# Altium schematic sheet Processor.SchDoc
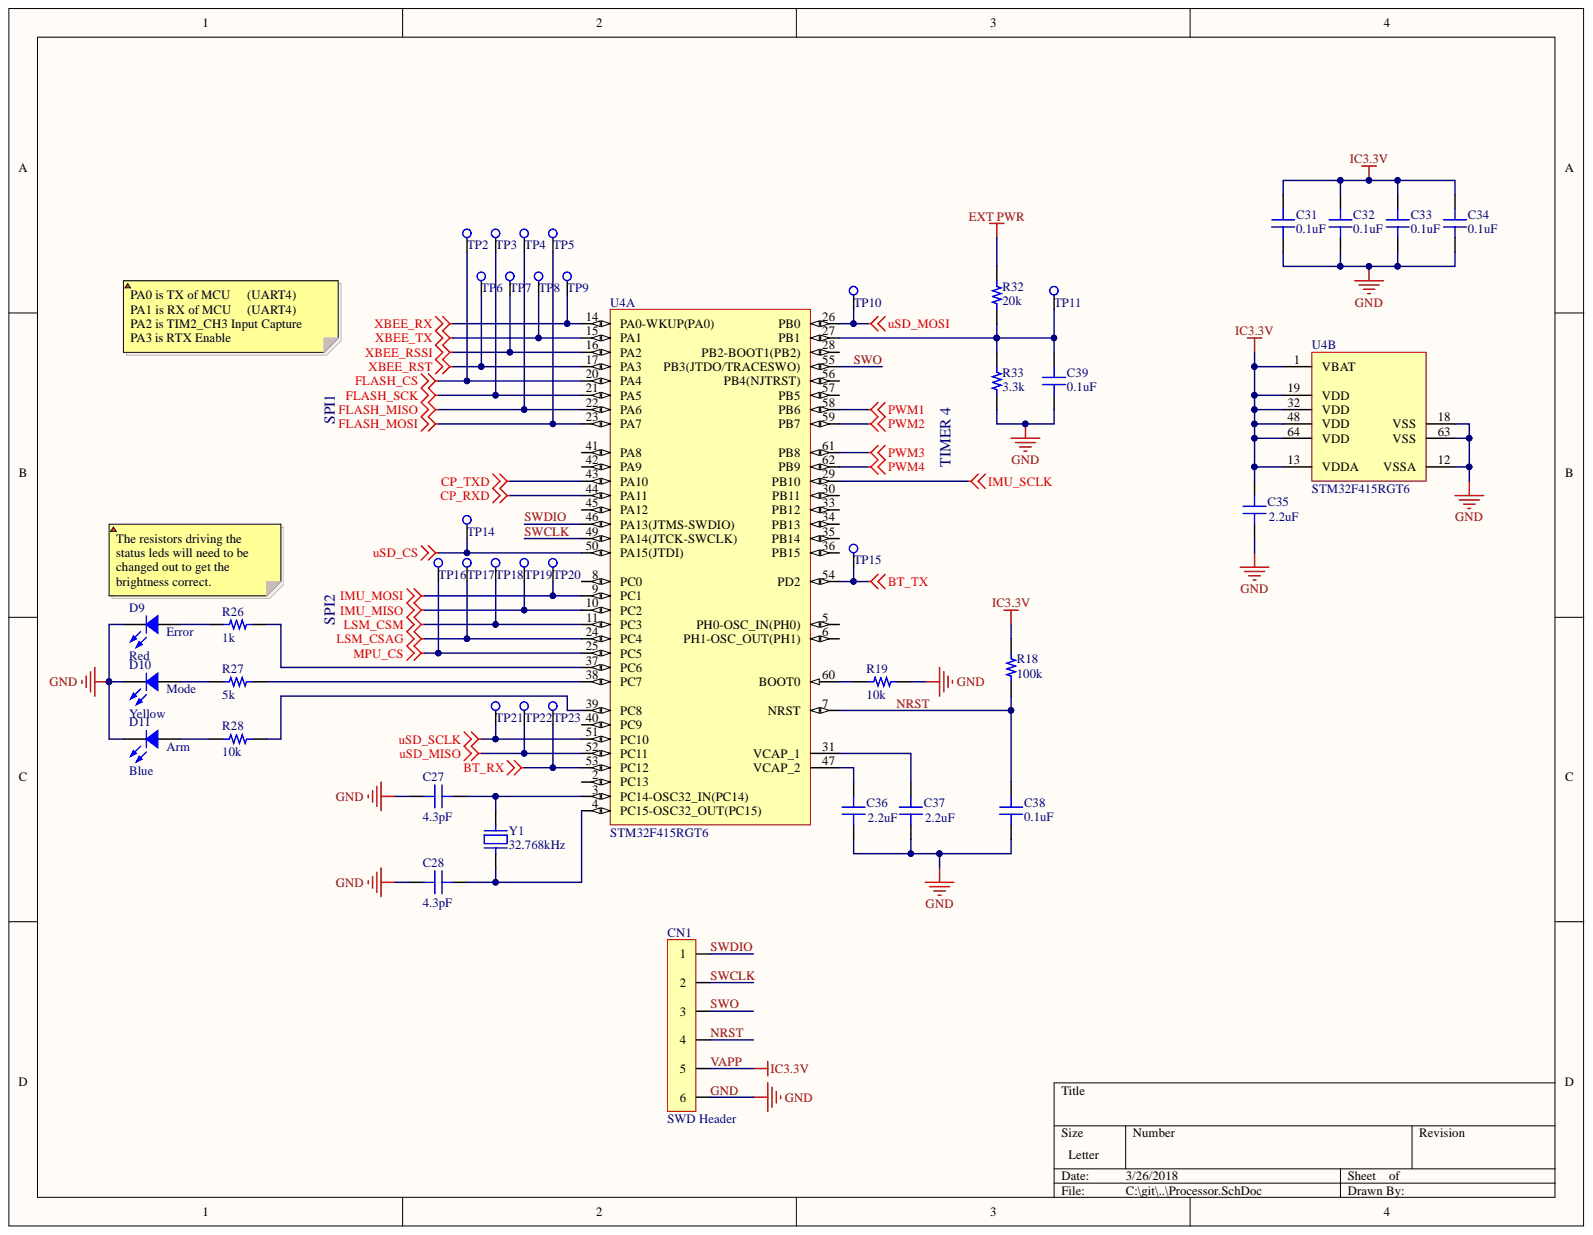
[diagram p0: Processor.SchDoc]

## Parts
C27  4.3pF  [CAP 0603/1608]
C28  4.3pF  [CAP 0603/1608]
C31  0.1uF  [CAP 0603/1608]
C32  0.1uF  [CAP 0603/1608]
C33  0.1uF  [CAP 0603/1608]
C34  0.1uF  [CAP 0603/1608]
C35  2.2uF  [CAP 0603/1608]
C36  2.2uF  [CAP 0603/1608]
C37  2.2uF  [CAP 0603/1608]
C38  0.1uF  [CAP 0603/1608]
C39  0.1uF  [CAP 0603/1608]
CN1  SWD Header  [Header_1x6]
D9  Red  [LED 0603/1608 RED]
D10  Yellow  [LED 0603/1608 YELLOW]
D11  Blue  [LED 0603/1608 BLUE]
R18  100k  [RES 0603/1608]
R19  10k  [RES 0603/1608]
R26  1k  [RES 0603/1608]
R27  5k  [RES 0603/1608]
R28  10k  [RES 0603/1608]
R32  20k  [RES 0603/1608]
R33  3.3k  [RES 0603/1608]
TP2  RCT-0C  [TE TP 0805]  (value not drawn)
TP3  RCT-0C  [TE TP 0805]  (value not drawn)
TP4  RCT-0C  [TE TP 0805]  (value not drawn)
TP5  RCT-0C  [TE TP 0805]  (value not drawn)
TP6  RCT-0C  [TE TP 0805]  (value not drawn)
TP7  RCT-0C  [TE TP 0805]  (value not drawn)
TP8  RCT-0C  [TE TP 0805]  (value not drawn)
TP9  RCT-0C  [TE TP 0805]  (value not drawn)
TP10  RCT-0C  [TE TP 0805]  (value not drawn)
TP11  RCT-0C  [TE TP 0805]  (value not drawn)
TP14  RCT-0C  [TE TP 0805]  (value not drawn)
TP15  RCT-0C  [TE TP 0805]  (value not drawn)
TP16  RCT-0C  [TE TP 0805]  (value not drawn)
TP17  RCT-0C  [TE TP 0805]  (value not drawn)
TP18  RCT-0C  [TE TP 0805]  (value not drawn)
TP19  RCT-0C  [TE TP 0805]  (value not drawn)
TP20  RCT-0C  [TE TP 0805]  (value not drawn)
TP21  RCT-0C  [TE TP 0805]  (value not drawn)
TP22  RCT-0C  [TE TP 0805]  (value not drawn)
TP23  RCT-0C  [TE TP 0805]  (value not drawn)
U4  STM32F415RGT6  [STM-LQFP64_N]  (parts A,B)
Y1  32.768kHz  [ABRACON ABS25]

## Nets
BT_RX: TP23.1 U4.53(PC12)
BT_TX: TP15.1 U4.54(PD2)
CP_RXD: U4.44(PA11)
CP_TXD: U4.43(PA10)
EXT PWR: R32.2
FLASH_CS: TP2.1 U4.20(PA4)
FLASH_MISO: TP4.1 U4.22(PA6)
FLASH_MOSI: TP5.1 U4.23(PA7)
FLASH_SCK: TP3.1 U4.21(PA5)
IMU_MISO: TP19.1 U4.10(PC2)
IMU_MOSI: TP20.1 U4.9(PC1)
IMU_SCLK: U4.29(PB10)
LSM_CSAG: TP17.1 U4.24(PC4)
LSM_CSM: TP18.1 U4.11(PC3)
MPU_CS: TP16.1 U4.25(PC5)
PWM1: U4.58(PB6)
PWM2: U4.59(PB7)
PWM3: U4.61(PB8)
PWM4: U4.62(PB9)
uSD_CS: TP14.1 U4.50(PA15(JTDI))
uSD_MISO: TP22.1 U4.52(PC11)
uSD_MOSI: TP10.1 U4.26(PB0)
uSD_SCLK: TP21.1 U4.51(PC10)
XBEE_RSSI: TP7.1 U4.16(PA2)
XBEE_RST: TP6.1 U4.17(PA3)
XBEE_RX: TP9.1 U4.14(PA0-WKUP(PA0))
XBEE_TX: TP8.1 U4.15(PA1)
GND: C27.1 C28.1 C31.1 C32.1 C33.1 C34.1 C35.1 C36.1 C37.1 C38.1 C39.1 CN1.6 D9.2 D10.2 D11.2 R19.2 R33.1 U4.12(VSSA) U4.18(VSS) U4.63(VSS)
NRST: C38.2 CN1.4 R18.1 U4.7(NRST)
SWCLK: CN1.2 U4.49(PA14(JTCK-SWCLK))
SWDIO: CN1.1 U4.46(PA13(JTMS-SWDIO))
SWO: CN1.3 U4.55(PB3(JTDO/TRACESWO))
VAPP: C31.2 C32.2 C33.2 C34.2 C35.2 CN1.5 R18.2 U4.1(VBAT) U4.13(VDDA) U4.19(VDD) U4.32(VDD) U4.48(VDD) U4.64(VDD)
NetC27_2: C27.2 U4.3(PC14-OSC32_IN(PC14)) Y1.1
NetC28_2: C28.2 U4.4(PC15-OSC32_OUT(PC15)) Y1.4
NetC36_2: C36.2 U4.47(VCAP_2)
NetC37_2: C37.2 U4.31(VCAP_1)
NetC39_2: C39.2 R32.1 R33.2 TP11.1 U4.27(PB1)
NetD9_1: D9.1 R26.1
NetD10_1: D10.1 R27.1
NetD11_1: D11.1 R28.1
NetR19_1: R19.1 U4.60(BOOT0)
NetR26_2: R26.2 U4.37(PC6)
NetR27_2: R27.2 U4.38(PC7)
NetR28_2: R28.2 U4.39(PC8)

Not connected: U4 2(PC13) 5(PH0-OSC_IN(PH0)) 6(PH1-OSC_OUT(PH1)) 8(PC0) 28(PB2-BOOT1(PB2)) 30(PB11) 33(PB12) 34(PB13) 35(PB14) 36(PB15) 40(PC9) 41(PA8) 42(PA9) 45(PA12) 56(PB4(NJTRST)) 57(PB5)

## Library data of the parts
C27 C28 C31-C39 = CL10C4R3CB8NNNC: CAP CER 4.3PF 50V NP0 0603; Manufacturer: Samsung Electro-Mechanics America, Inc.; Database Table Name: Capacitor - Ceramic; TemperatureCoefficient: C0G, NP0; TemperatureRange: -55C ~ 125C; Tolerance: 0.25pF; Component Kind: Standard; ComponentLink1Description: Datasheet; ComponentLink1URL: http://www.samsungsem.com/kr/support/product-search/mlcc/CL10C4R3CB8NNNC.jsp; ComponentLink2Description: Digi-Key listing; ComponentLink2URL: http://digikey.com/product-detail/en/CL10C4R3CB8NNNC/1276-2309-2-ND/3887967; Device Package: 0603 (1608 Metric); Library Name: Celestial Library Database.DbLib; PartId: 5248; Part Number: CL10C4R3CB8NNNC; Price: 0.0034; Supplier 1: Digi-Key; Supplier Part Number 1: 1276-2309-2-ND; Type: -; Voltage: 50V
  C27 C28: Value: 4.3pF
  C31-C34 C38 C39: Value: 0.1uF
  C35-C37: Value: 2.2uF
CN1 = Header_1x6: Pin Spacing: 0.1in, 2.54mm; Component Kind: Standard; Library Name: PinHeader.SchLib; Type: Generic
D9 = LTST-C191KRKT: LED RED CLEAR 0603 SMD; Manufacturer: Lite-On Inc.; Current (Test): 20mA; Database Table Name: Chip LED; Millicandela: 54mcd; Value: Green; Viewing Angle: 130; Voltage Forward: 2V; Colour: Red; Component Kind: Standard; ComponentLink1Description: Datasheet; ComponentLink1URL: http://optoelectronics.liteon.com/upload/download/DS22-2000-223/S_110_LTST-C191KRKT.pdf; ComponentLink2Description: Digi-Key Listing; ComponentLink2URL: http://www.digikey.ca/product-detail/en/LTST-C191KRKT/160-1447-2-ND/386837; Device Package: 0603 (1608 Metric); Lens Transparency: Clear; Library Name: Celestial Library Database.DbLib; PartId: 7050; Part Number: LTST-C191KRKT; Price: 0.0521; Supplier 1: Digi-Key; Supplier Part Number 1: 160-1447-2-ND
D10 = LTST-C191KSKT: LED YELLOW CLEAR 0603 SMD; Manufacturer: Lite-On Inc.; Current (Test): 20mA; Database Table Name: Chip LED; Millicandela: 104mcd; Type: Surface Mount; Value: Green; Viewing Angle: 130; Voltage Forward: 2.1V; Colour: Yellow; Component Kind: Standard; ComponentLink1Description: Datasheet; ComponentLink1URL: http://optoelectronics.liteon.com/upload/download/DS22-2000-224/S_110_LTST-C191KSKT.pdf; ComponentLink2Description: Digi-Key Listing; ComponentLink2URL: http://www.digikey.ca/product-detail/en/LTST-C191KSKT/160-1448-2-ND/386839; Device Package: 0603 (1608 Metric); Lens Transparency: Clear; Library Name: Celestial Library Database.DbLib; PartId: 7053; Part Number: LTST-C191KSKT; Price: 0.0555; Supplier 1: Digi-Key; Supplier Part Number 1: 160-1448-2-ND
D11 = LTST-C193TBKT-5A: LED BLUE CLEAR 0603 SMD; Manufacturer: Lite-On Inc.; Current (Test): 5mA; Database Table Name: Chip LED; Millicandela: 15mcd; Type: Surface Mount; Value: Green; Viewing Angle: 130; Voltage Forward: 2.8V; Colour: Blue; Component Kind: Standard; ComponentLink1Description: Datasheet; ComponentLink1URL: http://optoelectronics.liteon.com/upload/download/DS22-2004-060/P_100_LTST-C193TBKT-5A.pdf; ComponentLink2Description: Digi-Key Listing; ComponentLink2URL: http://www.digikey.ca/product-detail/en/LTST-C193TBKT-5A/160-1827-2-ND/2053656; Device Package: 0603 (1608 Metric); Lens Transparency: Clear; Library Name: Celestial Library Database.DbLib; PartId: 7054; Part Number: LTST-C193TBKT-5A; Price: 0.0875; Supplier 1: Digi-Key; Supplier Part Number 1: 160-1827-2-ND
R18 R19 R26-R28 R32 R33 = ERJ-3EKF1002V: RES SMD 10K OHM 1% 1/10W 0603; Manufacturer: Panasonic Electronic Components; Database Table Name: Resistor - Chip; PowerRating: 0.1W, 1/10W; TemperatureCoefficient: 100ppm/C; TemperatureRange: -55C ~ 155C; Component Kind: Standard; ComponentLink1Description: Datasheet; ComponentLink1URL: http://industrial.panasonic.com/www-cgi/jvcr13pz.cgi?E+PZ+3+AOA0002+ERJ3EKF1002V+7+WW; ComponentLink2Description: Digi-Key listing; ComponentLink2URL: http://digikey.com/product-detail/en/ERJ-3EKF1002V/P10.0KHTR-ND/196066; Device Package: 0603 (1608 Metric); Library Name: Celestial Library Database.DbLib; PartId: 886; Part Number: ERJ-3EKF1002V; Price: 0.0038; Supplier 1: Digi-Key; Supplier Part Number 1: P10.0KHTR-ND; Tolerance: 1%; Type: Thick Film
  R18 R19 R28: Value: 10k
  R26: Value: 1k
  R27: Value: 5k
  R32: Value: 20k
  R33: Value: 3.3k
TP2-TP11 TP14-TP23 = RCT-0C: 0805 PROBE PAD; Manufacturer: TE Connectivity AMP Connectors; Database Table Name: Test Point; Component Kind: Standard; ComponentLink1Description: Datasheet; ComponentLink1URL: http://www.te.com/commerce/DocumentDelivery/DDEController?Action=srchrtrv&DocNm=1773266&DocType=DS&DocLang=English; ComponentLink2Description: Digi-Key Listing; ComponentLink2URL: http://www.digikey.com/product-detail/en/te-connectivity-amp-connectors/RCT-0C/A106144CT-ND/3477801; Library Name: Celestial Library Database.DbLib; Mounting Type: Surface Mount; PartId: 15704; Part Number: RCT-0C; Price: 0.14; Supplier 1: Digi-Key; Supplier Part Number 1: A106144CT-ND; Type: PC Test Point
U4 = STM32F415RGT6: ARM Cortex-M4 32-bit MCU+FPU, 210 DMIPS, 1024 kB Flash, 192 kB Internal RAM, 51 I/Os, 64-pin LQFP, -40 to 85 degC, Tray; Manufacturer: STMicroelectronics; D/A Converter: 2x12-bit; FLASH Size (Prog) (kB): 1024; I/Os (High Current): 51; Internal RAM Size (kB): 192; Operating Frequency(F) (Processor speed) (MHz): 168; Supply Voltage(Vcc) max (V): 3.6; Supply Voltage(Vcc) min (V): 1.8; Component Kind: Standard; ComponentLink1Description: Manufacturer URL; ComponentLink1URL: http://www.st.com/web/en/home.html; ComponentLink2Description: Datasheet; ComponentLink2URL: http://www.st.com/st-web-ui/static/active/en/resource/technical/document/datasheet/DM00035129.pdf; ComponentLink3Description: Package Specification; ComponentLink3URL: http://www.st.com/st-web-ui/static/active/en/resource/technical/document/datasheet/DM00037051.pdf; Core: ARM Cortex-M4; DatasheetVersion: Rev. 4; Library Name: STMicroelectronics STM32 F4.IntLib; Mounting Technology: Surface Mount; PackageDescription: 64-Pin Low Profile Quad Flat Package, 10 x 10 mm Body, 0.5 mm Pitch; PackageReference: LQFP64; PackageVersion: Rev. 4, 06/2013; Packing: Tray; PartNumber: STM32F415RGT6; Supplier 1: Digi-Key; Supplier 2: Farnell; Supplier 3: Newark; Supplier 4: Mouser; Supplier Part Number 1: 497-11907-ND; Supplier Part Number 2: 2064370; Supplier Part Number 3: 73T2335; Supplier Part Number 4: 511-STM32F415RGT6
Y1 = ABS25-32.768KHZ-6-T: CRYSTAL 32.7680KHZ 6PF SMD; Manufacturer: Abracon LLC; Database Table Name: Oscillator - Crystal; ESR: 50 kOhm; Frequency Tolerance: 20ppm; Operating Mode: Fundamental; Component Kind: Standard; ComponentLink1Description: Datasheet; ComponentLink1URL: http://www.abracon.com/Resonators/abs25.pdf; ComponentLink2Description: Digi-Key Listing; ComponentLink2URL: http://www.digikey.com/product-detail/en/ABS25-32.768KHZ-6-T/535-10240-2-ND/2217986; Device Package: 4-SOJ, 5.50mm pitch; Frequency: 32.768kHz; Frequency Stability: -; Library Name: Celestial Library Database.DbLib; Load Capacitance: 6pF; PartId: 8458; Part Number: ABS25-32.768KHZ-6-T; Price: 0.2025; Supplier 1: Digi-Key; Supplier Part Number 1: 535-10240-2-ND; Type: kHz Crystal (Tuning Fork)
